# Altium schematic sheet S7CAS-PLEIADES-CARTE-MERE_P01_MOTORS.SchDoc
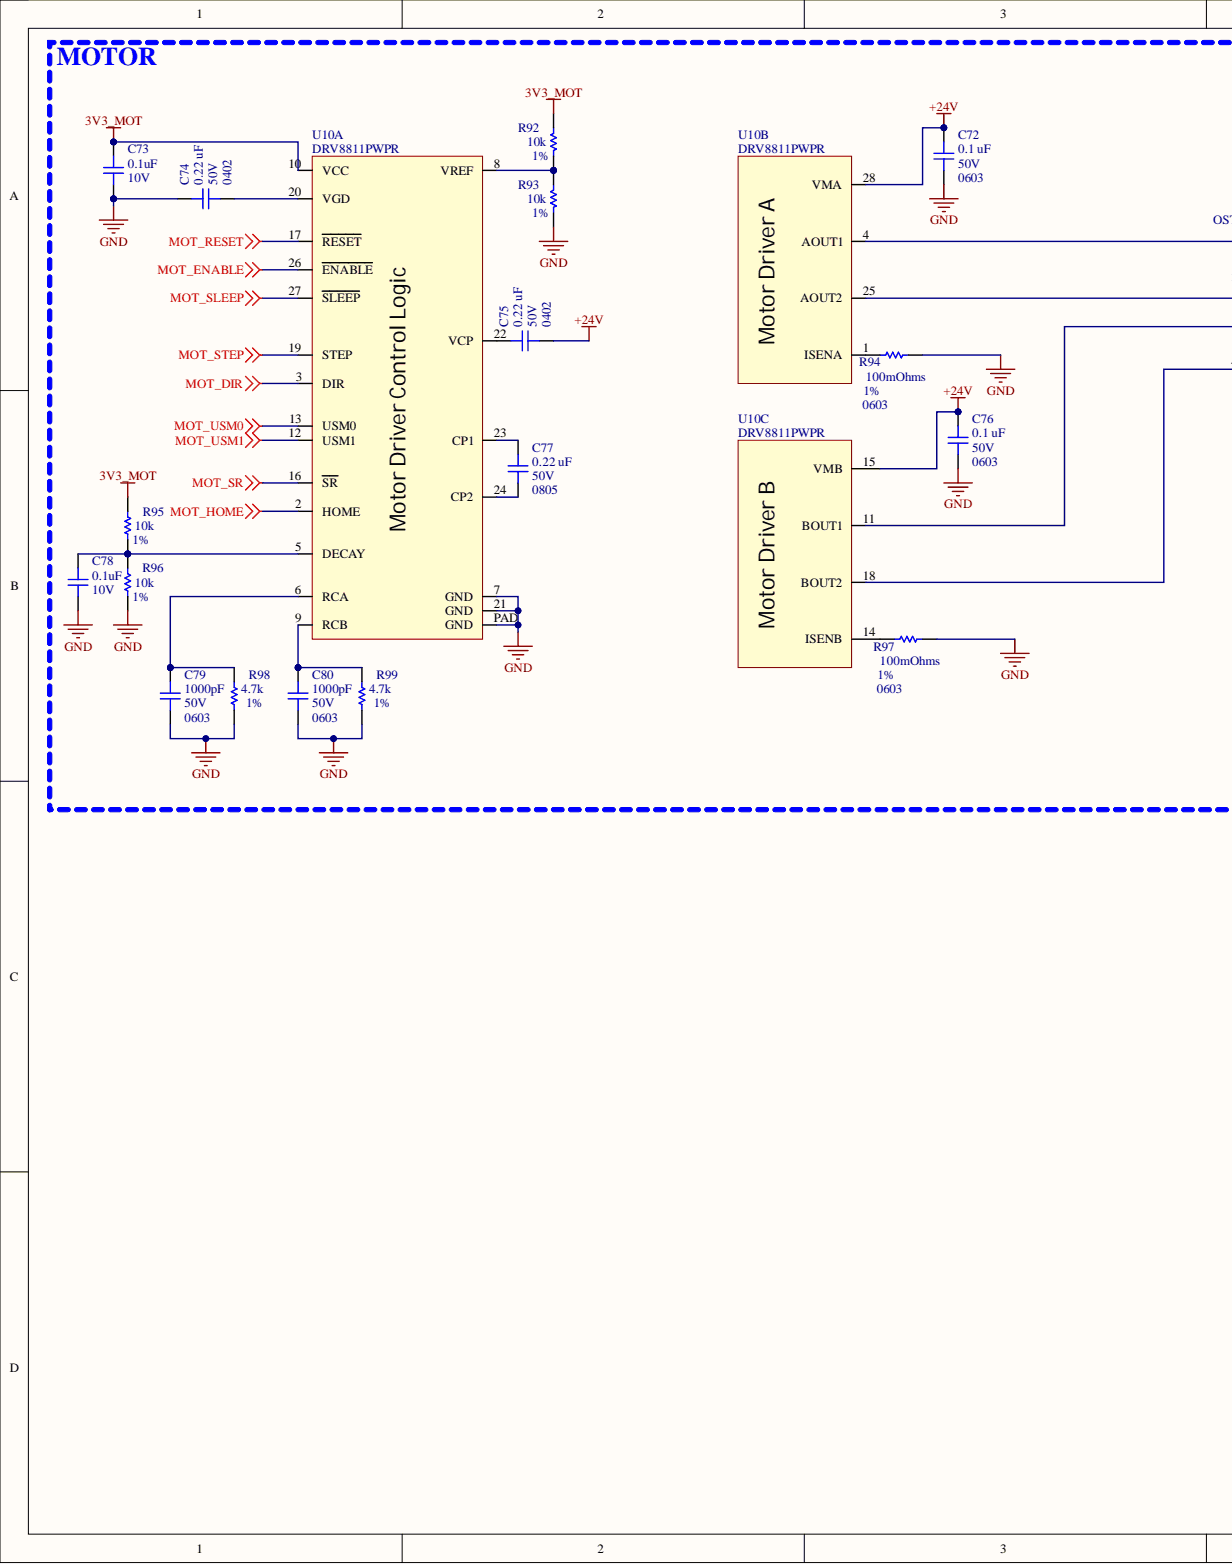
[diagram p0: S7CAS-PLEIADES-CARTE-MERE_P01_MOTORS.SchDoc, left]
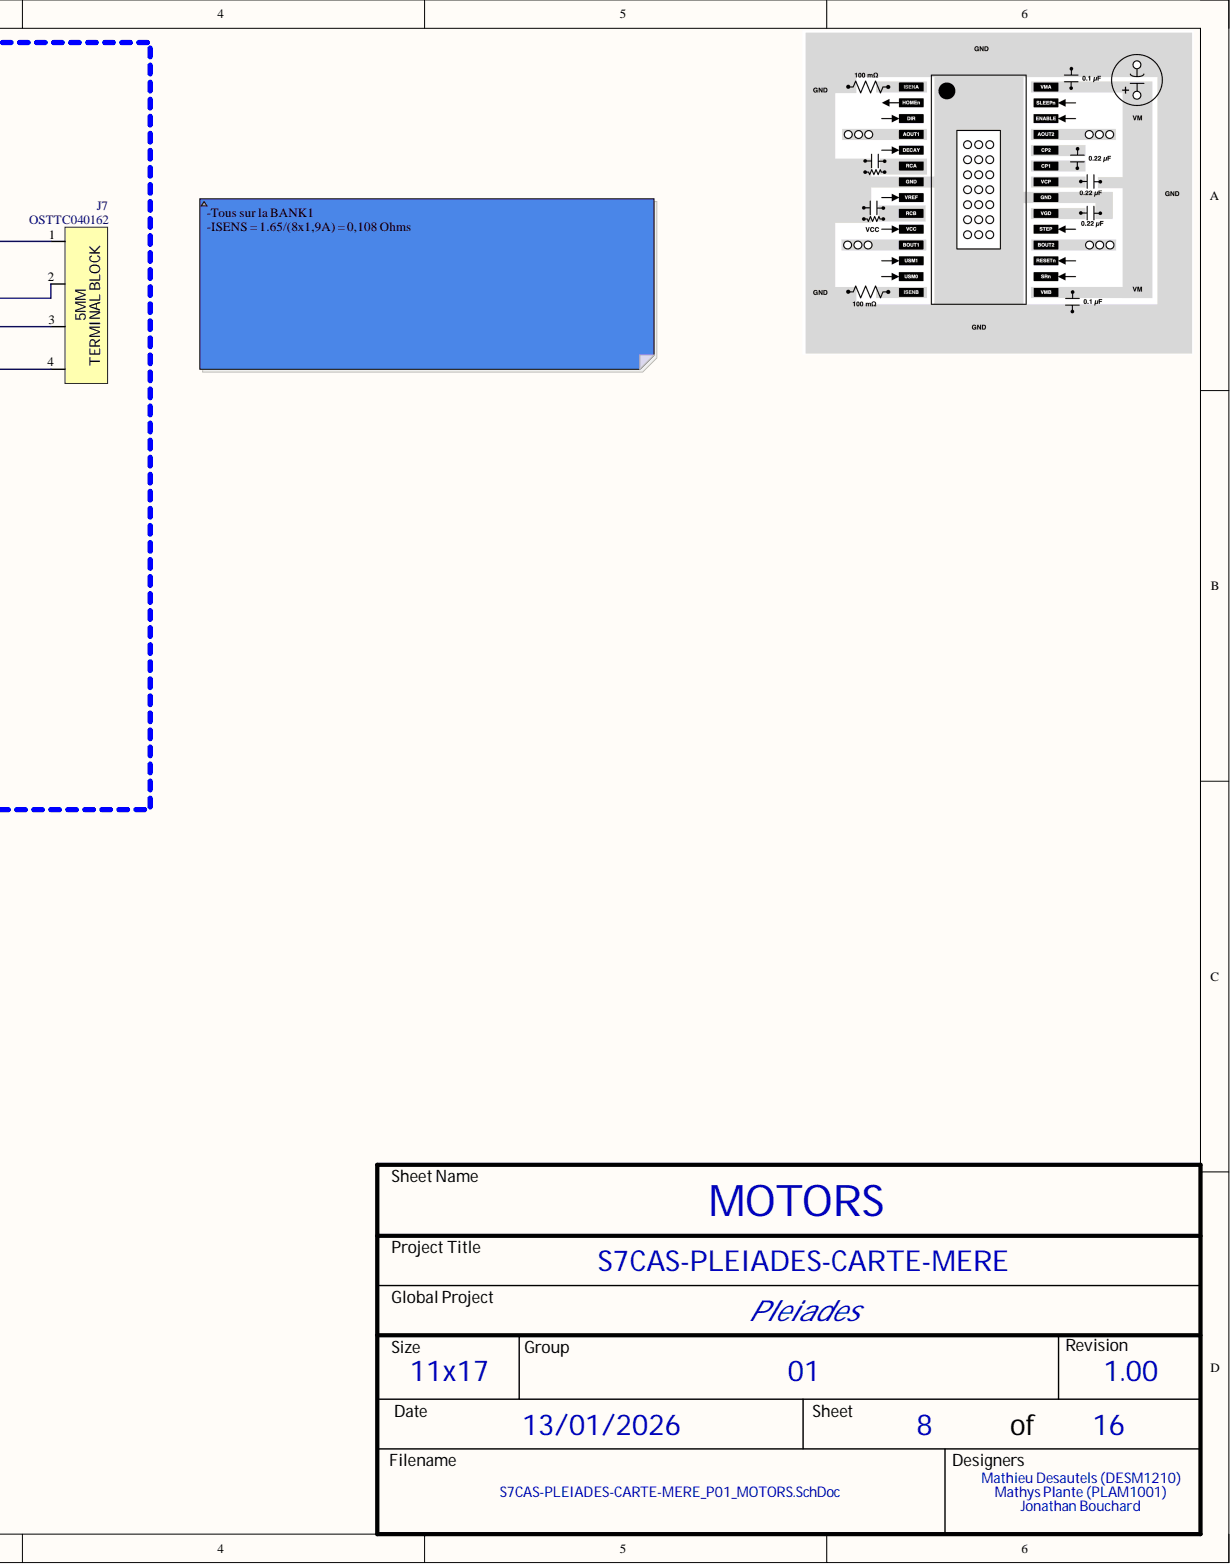
[diagram p1: S7CAS-PLEIADES-CARTE-MERE_P01_MOTORS.SchDoc, right]

## Parts
C72  value 0.1 uF  Package: 0603  Voltage: 50V  [CAP_0603]  (hidden comment: CAP - 0603)
C73  value 0.1uF  Voltage: 10V  [CAP_0402]  (hidden comment: CAP - 0402)
C74  value 0.22 uF  Package: 0402  Voltage: 50V  [CAP_0402]  (hidden comment: CAP - 0402)
C75  value 0.22 uF  Package: 0402  Voltage: 50V  [CAP_0402]  (hidden comment: CAP - 0402)
C76  value 0.1 uF  Package: 0603  Voltage: 50V  [CAP_0603]  (hidden comment: CAP - 0603)
C77  value 0.22 uF  Package: 0805  Voltage: 50V  [CAP_0805]  (hidden comment: CAP - 0805)
C78  value 0.1uF  Voltage: 10V  [CAP_0402]  (hidden comment: CAP - 0402)
C79  value 1000pF  Package: 0603  Voltage: 50V  [CAP_0603]  (hidden comment: CAP - 0603)
C80  value 1000pF  Package: 0603  Voltage: 50V  [CAP_0603]  (hidden comment: CAP - 0603)
J7  OSTTC040162  [CONN_TERMINAL_OSTTA040161]
R92  value 10k  Precision: 1%  [RES_0402]  (hidden comment: RES - 0402)
R93  value 10k  Precision: 1%  [RES_0402]  (hidden comment: RES - 0402)
R94  value 100mOhms  [RES_0603]  (hidden comment: RES - 0603)
R95  value 10k  Precision: 1%  [RES_0402]  (hidden comment: RES - 0402)
R96  value 10k  Precision: 1%  [RES_0402]  (hidden comment: RES - 0402)
R97  value 100mOhms  [RES_0603]  (hidden comment: RES - 0603)
R98  value 4.7k  Precision: 1%  [RES_0402]  (hidden comment: RES - 0402)
R99  value 4.7k  Precision: 1%  [RES_0402]  (hidden comment: RES - 0402)
U10  DRV8811PWPR  [POW_MOTOR_DRV8811PWPR]  (parts A,B,C)

## Nets
3V3_MOT: C73.2 R92.2 R95.2 U10.10(VCC)
+24V: C72.2 C75.1 C76.2 U10.15(VMB) U10.28(VMA)
GND: C72.1 C73.1 C74.2 C76.1 C78.1 C79.1 C80.1 R93.1 R94.1 R96.1 R97.1 R98.1 R99.1 U10.7(GND) U10.21(GND) U10.PAD(GND)
MOT_DIR: U10.3(DIR)
MOT_ENABLE: U10.26(ENABLE)
MOT_HOME: U10.2(HOME)
MOT_RESET: U10.17(RESET)
MOT_SLEEP: U10.27(SLEEP)
MOT_SR: U10.16(SR)
MOT_STEP: U10.19(STEP)
MOT_USM0: U10.13(USM0)
MOT_USM1: U10.12(USM1)
NetC74_1: C74.1 U10.20(VGD)
NetC75_2: C75.2 U10.22(VCP)
NetC77_1: C77.1 U10.24(CP2)
NetC77_2: C77.2 U10.23(CP1)
NetC78_2: C78.2 R95.1 R96.2 U10.5(DECAY)
NetC79_2: C79.2 R98.2 U10.6(RCA)
NetC80_2: C80.2 R99.2 U10.9(RCB)
NetJ7_1: J7.1 U10.4(AOUT1)
NetJ7_2: J7.2 U10.25(AOUT2)
NetJ7_3: J7.3 U10.11(BOUT1)
NetJ7_4: J7.4 U10.18(BOUT2)
NetR92_1: R92.1 R93.2 U10.8(VREF)
NetR94_2: R94.2 U10.1(ISENA)
NetR97_2: R97.2 U10.14(ISENB)

## Library data of the parts
C72 C76 = CAP - 0603: 0.1 µF ±10% 50V Ceramic Capacitor X7R 0603 (1608 Metric); Mfg Part Number: C0603C104K5RACTU; Mfg: KEMET; Component Kind: Standard; Library Name: s7cas_lib2023.intlib; Precision: 10%; CreatedBy: Jonathan Bouchard
C73 C78 = CAP - 0402: 0.1 µF ±10% 10V Ceramic Capacitor X5R 0402 (1005 Metric); Mfg Part Number: CL05A104KP5NNNC; Mfg: Samsung Electro-Mechanics; Component Kind: Standard; Library Name: s7cas_lib2023.intlib; Package: 0402; Part Number #1: CL05A104KP5NNNC; Precision: 10%; CreatedBy: Jonathan Bouchard
C74 C75 = CAP - 0402: 0.22 µF ±10% 50V Ceramic Capacitor X5R 0402 (1005 Metric); Mfg Part Number: UMK105BJ224KV-F; Mfg: Taiyo Yuden; Component Kind: Standard; Library Name: s7cas_lib2023.intlib; Precision: 10%; CreatedBy: Jonathan Bouchard
C77 = CAP - 0805: 0.22 µF ±10% 50V Ceramic Capacitor X7R 0805 (2012 Metric); Mfg Part Number: C0805C224K5RACTU; Component Kind: Standard; Library Name: s7cas_lib2023.intlib; Precision: 10%; CreatedBy: Jonathan Bouchard
C79 C80 = CAP - 0603: 1000 pF ±10% 50V Ceramic Capacitor X7R 0603 (1608 Metric); Mfg Part Number: C0603C102K5RACTU; Mfg: KEMET; Component Kind: Standard; Library Name: s7cas_lib2023.intlib; Precision: 10%; CreatedBy: Jonathan Bouchard
J7 = CONN_TERMINAL_OSTTC040162: CONN -  TERMINAL BLOCK 4POS 5MM - TH; Mfg: On Shore Technology Inc.; Component Kind: Standard; HelpURL: http://www.on-shore.com/wp-content/uploads/2015/09/osttcxx0162.pdf; Library Name: s7cas_lib2023.intlib; Mfg Part Number: OSTTC040162; Package: TH-4; Supplier 1: Digikey; Supplier Part Number 1: ED2602-ND; Temperature: -30°C ~ 105°C; CreatedBy: Jonathan Bouchard
R92 R93 R95 R96 = RES - 0402: 10 kOhms ±1% 0.063W, 1/16W Chip Resistor 0402 (1005 Metric) Automotive AEC-Q200 Thick Film; Mfg Part Number: RMCF0402FT10K0; Voltage: 75V; Component Kind: Standard; Library Name: s7cas_lib2023.intlib; Package: 0402; Part Number #1: RMCF0402FT10K0; Power: 1/16W; CreatedBy: Jonathan Bouchard
R94 R97 = RES - 0603: 100 mOhms ±1% 0.333W, 1/3W Chip Resistor 0603 (1608 Metric) Automotive AEC-Q200, Current Sense Thick Film; Mfg Part Number: ERJ-3BWFR100V; Mfg: Panasonic Electronic Components; Component Kind: Standard; Library Name: s7cas_lib2023.intlib; Package: 0603; Precision: 1%; CreatedBy: Jonathan Bouchard
R98 R99 = RES - 0402: 4.7 kOhms ±1% 0.063W, 1/16W Chip Resistor 0402 (1005 Metric) Moisture Resistant Thick Film; Mfg Part Number: RC0402FR-074K7L; Voltage: 75V; Component Kind: Standard; Library Name: s7cas_lib2023.intlib; Package: 0402; Part Number #1: RC0402FR-074K7L; Power: 1/16W; CreatedBy: Jonathan Bouchard
U10 = POW_MOTOR_DRV8811PWPR: POW - STEPPER MOTOR DRIVER - SOP-28; Mfg: Texas Instrument; Voltage: 3 V ~ 5.5 V / 8 V ~ 38 V; Component Kind: Standard; HelpURL: http://www.ti.com/lit/ds/symlink/drv8811.pdf; Library Name: s7cas_lib2023.intlib; Mfg Part Number: DRV8811PWPR; Package: SOP-28; Supplier 1: Digikey; Supplier Part Number 1: 296-24591-1-ND; Temperature: -40°C ~ 150°C (TJ); CreatedBy: Jonathan Bouchard
